# Altium schematic sheet Sch1 - Conectores.SchDoc("Sch1 - Conectores")
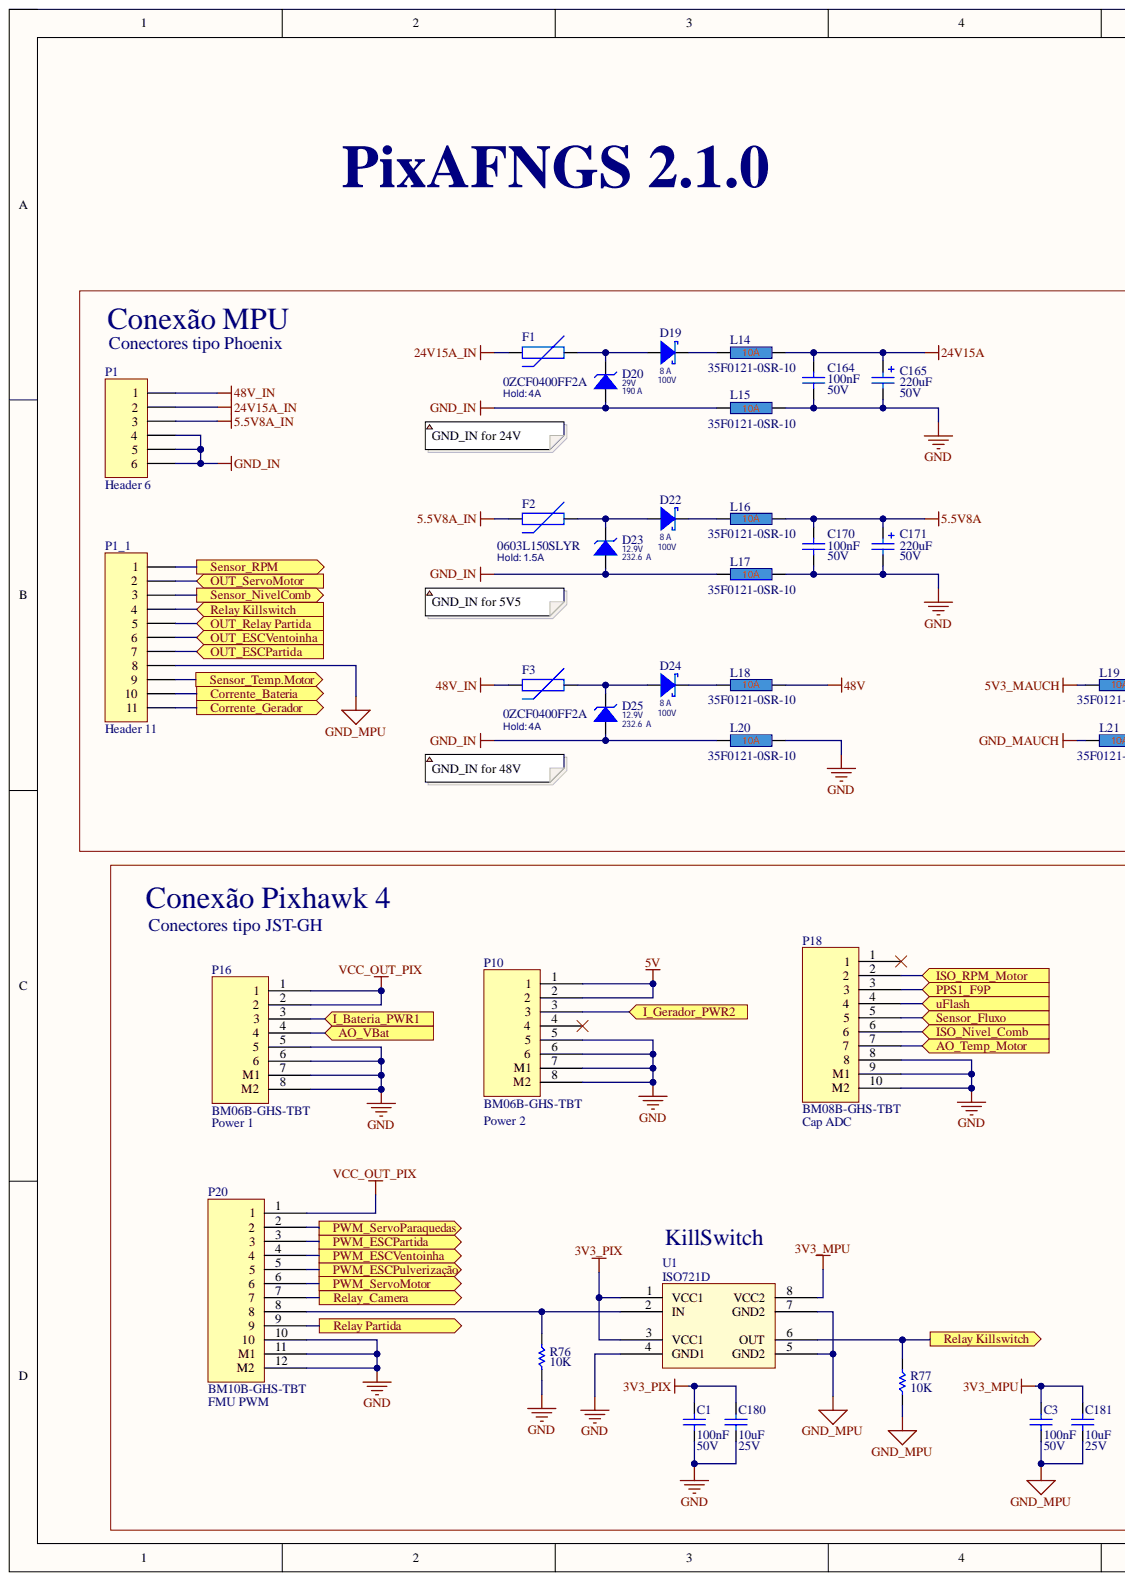
[diagram p0: Sch1 - Conectores.SchDoc, left]
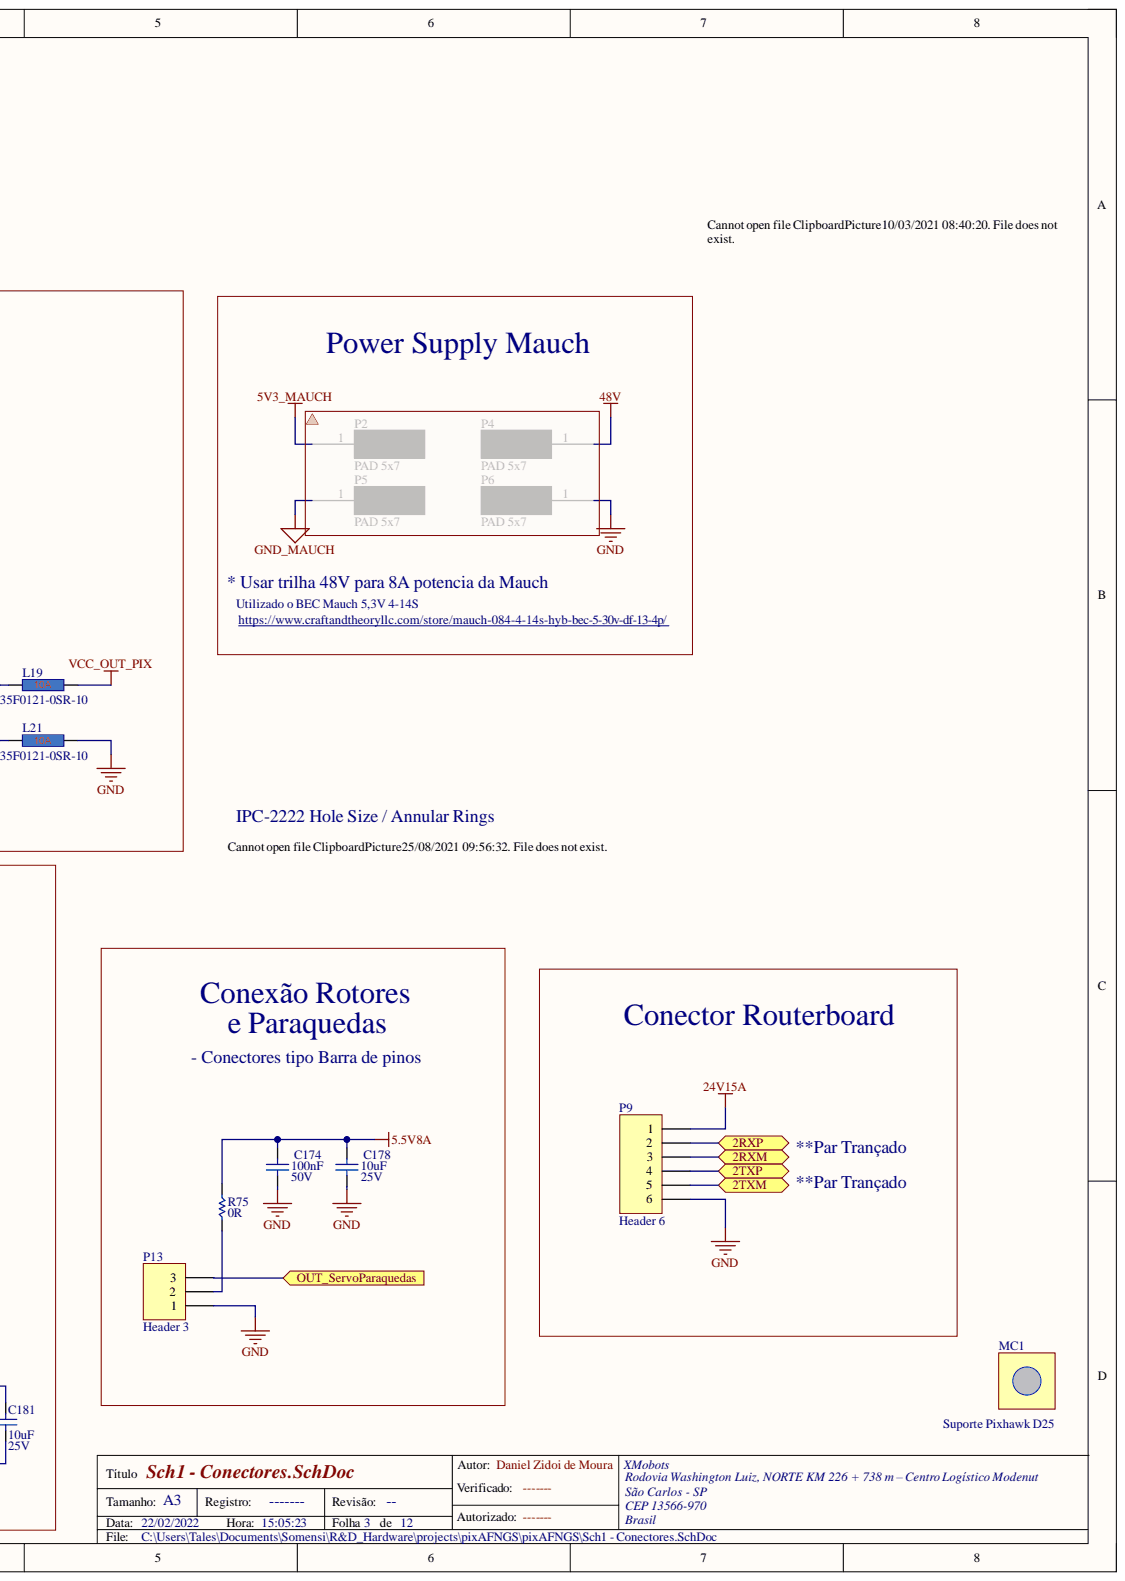
[diagram p1: Sch1 - Conectores.SchDoc, right]

## Parts
C1  Capacitance: 100nF  Voltage: 50V  [0603]  (hidden comment: CL10B104MB8NNNC)
C3  Capacitance: 100nF  Voltage: 50V  [0603]  (hidden comment: CL10B104MB8NNNC)
C164  Capacitance: 100nF  Voltage: 50V  [CAP-XMO-0603]  (hidden comment: CL10B104MB8NNNC)
C165  Capacitance: 220uF  Voltage: 50V  [C-ELE-SMD-10.3x10.3x10.2]  (hidden comment: EEH-ZS1H221P)
C170  Capacitance: 100nF  Voltage: 50V  [CAP-XMO-0603]  (hidden comment: CL10B104MB8NNNC)
C171  Capacitance: 220uF  Voltage: 50V  [C-ELE-SMD-10.3x10.3x10.2]  (hidden comment: EEH-ZS1H221P)
C174  Capacitance: 100nF  Voltage: 50V  [CAP-XMO-0603]  (hidden comment: CL10B104MB8NNNC)
C178  Capacitance: 10uF  Voltage: 25V  [0603]  (hidden comment: GRM188R61E106KA73J)
C180  Capacitance: 10uF  Voltage: 25V  [CAP-XMO-0603]  (hidden comment: GRM188R61E106KA73J)
C181  Capacitance: 10uF  Voltage: 25V  [CAP-XMO-0603]  (hidden comment: GRM188R61E106KA73J)
D19  If - Corrente direta: 8 A  Vrrm - Tensão inversa repetitiva: 100V  [SOD-123]  (hidden comment: PMEG400V8PAM10S-M3/I5EGWX)
D20  If - Corrente direta: 190 A  Vrrm - Tensão inversa repetitiva: 29V  [SOD-123]  (hidden comment: 8.0SMDJ26A)
D22  If - Corrente direta: 8 A  Vrrm - Tensão inversa repetitiva: 100V  [SOD-123]  (hidden comment: PMEG400V8PAM10S-M3/I5EGWX)
D23  If - Corrente direta: 232.6  A  VC: 12.9V  [SOD-123]  (hidden comment: 3.0SMCJ7.5CA)
D24  If - Corrente direta: 8 A  Vrrm - Tensão inversa repetitiva: 100V  [SOD-123]  (hidden comment: PMEG400V8PAM10S-M3/I5EGWX)
D25  If - Corrente direta: 232.6  A  VC: 12.9V  [SOD-123]  (hidden comment: 3.0SMCJ7.5CA)
F1  0ZCF0400FF2A  Hold Current: Hold: 4A  [EMI FILTER_1612]
F2  0603L150SLYR  Hold Current: Hold: 1.5A  [EMI FILTER_1612]
F3  0ZCF0400FF2A  Hold Current: Hold: 4A  [EMI FILTER_1612]
L14  35F0121-0SR-10  Rating: 10A  [EMI FILTER_1612]
L15  35F0121-0SR-10  Rating: 10A  [EMI FILTER_1612]
L16  35F0121-0SR-10  Rating: 10A  [EMI FILTER_1612]
L17  35F0121-0SR-10  Rating: 10A  [EMI FILTER_1612]
L18  35F0121-0SR-10  Rating: 10A  [EMI FILTER_1612]
L19  35F0121-0SR-10  Rating: 10A  [EMI FILTER_1612]
L20  35F0121-0SR-10  Rating: 10A  [EMI FILTER_1612]
L21  35F0121-0SR-10  Rating: 10A  [EMI FILTER_1612]
MC1  Suporte Pixhawk D25  [Base Pixhawk D25]
P1  Header 6  [CONECTOR-6]
P1_1  Header 11  [HDR1X11]
P9  Header 6  [HDR1X6]
P10  BM06B-GHS-TBT  [BM06B-GHS-TBT]
P13  Header 3  [HDR1X3]
P16  BM06B-GHS-TBT  [BM06B-GHS-TBT]
P18  BM08B-GHS-TBT  [BM08B-GHS-TBT]
P20  BM10B-GHS-TBT  [BM10B-GHS-TBT]
R75  Resistance: 0R  [RES-XMO-0603]  (hidden comment: RMCF0603ZT0R00)
R76  Resistance: 10K  [RES-XMO-0603]  (hidden comment: RMCF0603FT10K0)
R77  Resistance: 10K  [RES-XMO-0603]  (hidden comment: RMCF0603FT10K0)
U1  ISO721D  [SOIC-8_D-PDSO-G8]

## Ports
2RXM, 2RXP, 2TXM, 2TXP, AO_Temp_Motor, AO_VBat, Corrente_Bateria, Corrente_Gerador, I_Bateria_PWR1, I_Gerador_PWR2, ISO_Nivel_Comb, ISO_RPM_Motor, OUT_ESCPartida, OUT_ESCVentoinha, OUT_Relay Partida, OUT_ServoMotor, OUT_ServoParaquedas, PPS1_F9P, PWM_ESCPartida, PWM_ESCPulverização, PWM_ESCVentoinha, PWM_ServoMotor, PWM_ServoParaquedas, Relay Killswitch, Relay Partida, Relay_Camera, Sensor_Fluxo, Sensor_NivelComb, Sensor_RPM, Sensor_Temp.Motor, uFlash

## Nets
3V3_MPU: C3.2 C181.2 U1.8(VCC2)
3V3_PIX: C1.2 C180.2 U1.1(VCC1) U1.3(VCC1)
5.5V8A: C170.2 C171.1 C174.2 C178.2 L16.2 R75.2
5.5V8A_IN: F2.1 P1.3
5V: P10.1 P10.2
5V3_MAUCH: L19.1
24V15A: C164.2 C165.1 L14.2 P9.1
24V15A_IN: F1.1 P1.2
48V: L18.2
48V_IN: F3.1 P1.1
GND: C1.1 C164.1 C165.2 C170.1 C171.2 C174.1 C178.1 C180.1 L15.2 L17.2 L20.2 L21.2 P9.6 P10.5 P10.6 P10.7(M1) P10.8(M2) P13.1 P16.5 P16.6 P16.7(M1) P16.8(M2) P18.8 P18.9(M1) P18.10(M2) P20.10 P20.11(M1) P20.12(M2) R76.1 U1.4(GND1)
GND_IN: D20.2 D23.2 D25.2 L15.1 L17.1 L20.1 P1.4 P1.5 P1.6
GND_MAUCH: L21.1
GND_MPU: C3.1 C181.1 P1_1.8 R77.1 U1.5(GND2) U1.7(GND2)
VCC_OUT_PIX: L19.2 P16.1 P16.2 P20.1
2RXM: P9.3 ; port 2RXM
2RXP: P9.2 ; port 2RXP
2TXM: P9.5 ; port 2TXM
2TXP: P9.4 ; port 2TXP
AO_Temp_Motor: P18.7 ; port AO_Temp_Motor
AO_VBat: P16.4 ; port AO_VBat
Corrente_Bateria: P1_1.10 ; port Corrente_Bateria
Corrente_Gerador: P1_1.11 ; port Corrente_Gerador
I_Bateria_PWR1: P16.3 ; port I_Bateria_PWR1
I_Gerador_PWR2: P10.3 ; port I_Gerador_PWR2
ISO_Nivel_Comb: P18.6 ; port ISO_Nivel_Comb
ISO_RPM_Motor: P18.2 ; port ISO_RPM_Motor
OUT_ESCPartida: P1_1.7 ; port OUT_ESCPartida
OUT_ESCVentoinha: P1_1.6 ; port OUT_ESCVentoinha
OUT_Relay Partida: P1_1.5 ; port OUT_Relay Partida
OUT_ServoMotor: P1_1.2 ; port OUT_ServoMotor
OUT_ServoParaquedas: P13.3 ; port OUT_ServoParaquedas
PPS1_F9P: P18.3 ; port PPS1_F9P
PWM_ESCPartida: P20.3 ; port PWM_ESCPartida
PWM_ESCPulverização: P20.5 ; port PWM_ESCPulverização
PWM_ESCVentoinha: P20.4 ; port PWM_ESCVentoinha
PWM_ServoMotor: P20.6 ; port PWM_ServoMotor
PWM_ServoParaquedas: P20.2 ; port PWM_ServoParaquedas
Relay Killswitch: P1_1.4 R77.2 U1.6(OUT) ; port Relay Killswitch
Relay Partida: P20.9 ; port Relay Partida
Relay_Camera: P20.7 ; port Relay_Camera
Sensor_Fluxo: P18.5 ; port Sensor_Fluxo
Sensor_NivelComb: P1_1.3 ; port Sensor_NivelComb
Sensor_RPM: P1_1.1 ; port Sensor_RPM
Sensor_Temp.Motor: P1_1.9 ; port Sensor_Temp.Motor
uFlash: P18.4 ; port uFlash
NetD19_1: D19.1 L14.1
NetD19_2: D19.2 D20.1 F1.2
NetD22_1: D22.1 L16.1
NetD22_2: D22.2 D23.1 F2.2
NetD24_1: D24.1 L18.1
NetD24_2: D24.2 D25.1 F3.2
NetP13_2: P13.2 R75.1
NetP20_8: P20.8 R76.2 U1.2(IN)

Not connected: P10 4; P18 1

## Library data of the parts
C1 C3 C164 C170 C174 = C-100nF-50V-X7R-0603: Capacitor 100nF 50V X7R 0603; Component Kind: Standard; Part Number: CL10B104MB8NNNC; Library Name: xmobots_-_comp_library.IntLib
C165 C171 = C-ELE-220uF-50V-20%-SMD: Electrolytic Capacitor 220uF 50V SMD; Component Kind: Standard; Part Number: EEH-ZS1H221P; Library Name: xmobots_-_comp_library.IntLib
C178 C180 C181 = C-CER-10uF-25V-X5R-0603: Capacitor 10uF 25V X5R 0603; Component Kind: Standard; Part Number: GRM188R61E106KA73J; Library Name: xmobots_-_comp_library.IntLib
D19 D22 D24 = D-SCHOTTKY-100V-8A-V8PAM10S-M3/I-SMPA(DO-221BC): Schottky Diode  SMPA (DO-221BC); Manufacturer: Vishay; Component Kind: Standard; Manufacturer Part Number: V8PAM10S-M3/I; Library Name: xmobots_-_comp_library.IntLib
D20 = D-TVS-VR@26V-VBR@28V9-IPP@190A-DO-214AB: Schottky Diode SMPA (DO-221BC); Manufacturer: Vishay; Manufacturer Part Number: V8PAM10S-M3/I; Component Kind: Standard; Library Name: xmobots_-_comp_library.IntLib
D23 D25 = D-TVS-VR@26V-VBR@28V9-IPP@190A-DO-214AB_1: Schottky Diode SMPA (DO-221BC); Manufacturer: Littelfuse; VR: 7.5V; Component Kind: Standard; Manufacturer Part Number: 3.0SMCJ7.5CA; Library Name: xmobots_-_comp_library.IntLib
F1 F3 = F-PTC: Power Supply FB 10A; Time to trip: 5s; Trip Current: Trip: 8A; Component Kind: Standard; Library Name: xmobots_-_comp_library.IntLib
F2 = F-PTC_1: Power Supply FB 10A; Time to trip: 5s; Trip Current: Trip: 3A; Component Kind: Standard; Library Name: xmobots_-_comp_library.IntLib
L14-L21 = L-EMI FILTER-LAIRD-35F0121-0SR-10-10A-25%-1612: Power Supply FB 10A; Tolerance: 25%; Value: 36R@100MHz; Component Kind: Standard; Library Name: xmobots_-_comp_library.IntLib
MC1 = Suporte Pixhawk D25: Suporte Hellerman TMA0001A; Component Kind: Standard; Library Name: XM_Connector.SchLib
P1 P9 = Header 6: Header, 6-Pin; Component Kind: Standard; LatestRevisionDate: 17-Jul-2002; LatestRevisionNote: Re-released for DXP Platform.; Library Name: Miscellaneous Connectors.IntLib; Signal Integrity: Connector; Publisher: Altium Limited
P1_1 = Header 11: Header, 11-Pin; Component Kind: Standard; LatestRevisionDate: 17-Jul-2002; LatestRevisionNote: Re-released for DXP Platform.; Library Name: Miscellaneous Connectors.IntLib; Signal Integrity: Connector; Publisher: Altium Limited
P10 P16 = BM06B-GHS-TBT: JST GH 6 Vias Vertical; Component Kind: Standard; Library Name: XM_Connector.SchLib
P13 = Header 3: Header, 3-Pin; Component Kind: Standard; LatestRevisionDate: 17-Jul-2002; LatestRevisionNote: Re-released for DXP Platform.; Library Name: Miscellaneous Connectors.IntLib; Signal Integrity: Connector; Publisher: Altium Limited
P18 = BM08B-GHS-TBT: JST GH 8 Vias Vertical; Component Kind: Standard; Library Name: XM_Connector.SchLib
P20 = BM10B-GHS-TBT: JST GH 10 Vias Vertical; Component Kind: Standard; Library Name: XM_Connector.SchLib
R75 = R-0R-1/10W-1%-0603: Resistor 0 ohm 1/10W 0603; Tolerance: null; Component Kind: Standard; Part Number: RMCF0603ZT0R00; Power: 1/10W; Library Name: xmobots_-_comp_library.IntLib
R76 R77 = R-10K-1/10W-1%-0603: Resistor 10k ohms 1/10W 1% 0603; Component Kind: Standard; Part Number: RMCF0603FT10K0; Power: 1/10W; Tolerance: ±1%; Library Name: xmobots_-_comp_library.IntLib
U1 = ISO721D: 3.3-V / 5-V HIGH-SPEED DIGITAL ISOLATORS; Component Kind: Standard; Supplier 1: Digi-Key; Supplier Part Number 1: 296-19382-ND; Library Name: XM_IC.SchLib
